# Altium schematic sheet Filter.SchDoc
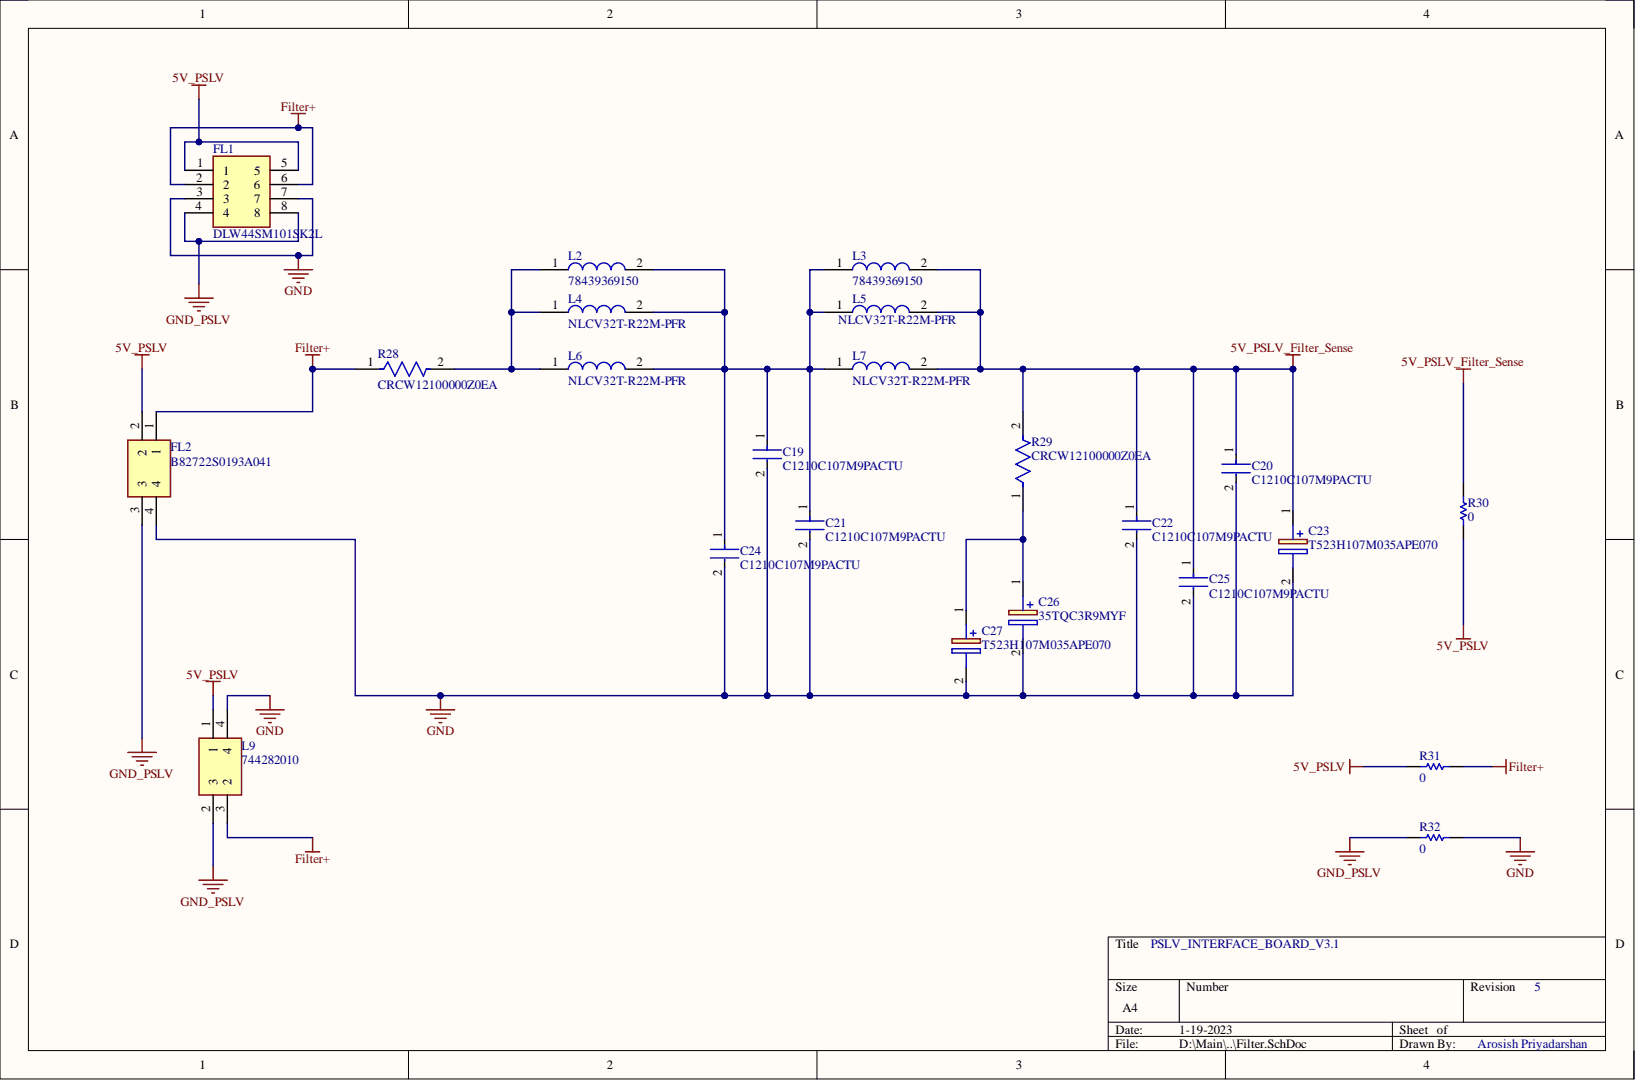
[diagram p0: Filter.SchDoc]

## Parts
C19  C1210C107M9PACTU  [FP-C1210C107M9PACTU-MFG]
C20  C1210C107M9PACTU  [FP-C1210C107M9PACTU-MFG]
C21  C1210C107M9PACTU  [FP-C1210C107M9PACTU-MFG]
C22  C1210C107M9PACTU  [FP-C1210C107M9PACTU-MFG]
C23  T523H107M035APE070  [CAPPM7360X200N]
C24  C1210C107M9PACTU  [FP-C1210C107M9PACTU-MFG]
C25  C1210C107M9PACTU  [FP-C1210C107M9PACTU-MFG]
C26  35TQC3R9MYF  [POSCAP_B1_B1S_B1G_B15G_B2_B2S]
C27  T523H107M035APE070  [CAPPM7360X200N]
FL1  DLW44SM101SK2L  [DLW44SM101SK2B]
FL2  B82722S0193A041  [B82722S0103A020]
L2  78439369150  [78439369150]
L3  78439369150  [78439369150]
L4  NLCV32T-R22M-PFR  [INDM3225X240N]
L5  NLCV32T-R22M-PFR  [INDM3225X240N]
L6  NLCV32T-R22M-PFR  [INDM3225X240N]
L7  NLCV32T-R22M-PFR  [INDM3225X240N]
L9  744282010  [WE-SCC_1260/121070]
R28  CRCW12100000Z0EA  [FP-CRCW1210-e3-IPC_A]
R29  CRCW12100000Z0EA  [FP-CRCW1210-e3-IPC_A]
R30  0  [RESC3216X70X50LL05T20]
R31  0  [RESC3216X70X50LL05T20]
R32  0  [RESC3216X70X50LL05T20]

## Nets
5V_PSLV: FL1.1 FL1.5 FL2.2 L9.1 R30.2 R31.1
5V_PSLV_Filter_Sense: C20.1 C22.1 C23.1 C25.1 L3.2 L5.2 L7.2 R29.2 R30.1
Filter+: FL1.2 FL1.6 FL2.1 L9.3 R28.1 R31.2
GND: C19.2 C20.2 C21.2 C22.2 C23.2 C24.2 C25.2 C26.2 C27.2 FL1.3 FL1.7 FL2.4 L9.4 R32.2
GND_PSLV: FL1.4 FL1.8 FL2.3 L9.2 R32.1
NetC19_1: C19.1 C21.1 C24.1 L2.2 L3.1 L4.2 L5.1 L6.2 L7.1
NetC26_1: C26.1 C27.1 R29.1
NetL2_1: L2.1 L4.1 L6.1 R28.2

## Library data of the parts
C19-C22 C24 C25 = CMP-2000-06018-2: CAP CER 100UF 6.3V X5R 1210; Dielectric Material: Ceramic; Dissipation Factor: 5%; Tolerance: 20%; Capacitance: 100uF; Case Code (Imperial): 1210; Case Code (Metric): 3225; Case/Package: 1210; Component Kind: Standard; Construction: Flat; Contact Plating: Tin; Depth: 2.5mm; Dielectric: X5R; Height: 2.5mm; Lead Free: Lead Free; Length: 3.2mm; Library Name: Altium Content Vault; Max Operating Temperature: 85°C; Min Operating Temperature: -55°C; Mount: Surface Mount; Number of Pins: 2; Package Quantity: 1; Packaging: Tape and Reel; Radiation Hardening: No; REACH SVHC: No SVHC; RoHS Compliant: Yes; Series: C; Termination: SMD/SMT; Thickness: 0.11inch; Voltage: 6.3V; Voltage Rating: 6.3V; Voltage Rating (DC): 6.3V; Width: 2.5mm
C23 C27 = T523H107M035APE070: Capacitor Polarised; Manufacturer_Name: KEMET; Arrow Part Number: T523H107M035APE070; Arrow Price/Stock: https://www.arrow.com/en/products/t523h107m035ape070/kemet-corporation; Component Kind: Standard; Datasheet Link: https://content.kemet.com/datasheets/KEM_T2079_SSD.pdf; Height: 2mm; Manufacturer_Part_Number: T523H107M035APE070; Mouser Part Number: 80-T523H107M35APE070; Mouser Price/Stock: https://www.mouser.co.uk/ProductDetail/KEMET/T523H107M035APE070?qs=unwgFEO1A6s1KPLWumNkZA%3D%3D
C26 = 35TQC3R9MYF: Capacitor Polarised; Manufacturer_Name: Panasonic; Arrow Part Number: 35TQC3R9MYF; Arrow Price/Stock: https://www.arrow.com/en/products/35tqc3r9myf/panasonic; Component Kind: Standard; Datasheet Link: http://industrial.panasonic.com/cdbs/www-data/pdf/AAA8000/AAA8000C62.pdf; Manufacturer_Part_Number: 35TQC3R9MYF; Mouser Part Number: 667-35TQC3R9MYF; Mouser Price/Stock: https://www.mouser.co.uk/ProductDetail/Panasonic/35TQC3R9MYF?qs=OE1iw1LrrPH4tD8vcXvqxw%3D%3D
FL1 = DLW44SM101SK2L: Filter; Manufacturer_Name: Murata Electronics; Component Kind: Standard; Datasheet Link: https://www.murata.com/en-us/products/productdetail?partno=DLW44SM101SK2%23; Height: 1.5mm; Manufacturer_Part_Number: DLW44SM101SK2L; Mouser Part Number: 81-DLW44SM101SK2L; Mouser Price/Stock: https://www.mouser.co.uk/ProductDetail/Murata-Electronics/DLW44SM101SK2L?qs=7EBvPakHacXuNrKukXyu4w%3D%3D
FL2 = B82722S0193A041: Filter; Manufacturer_Name: TDK; Component Kind: Standard; Datasheet Link: https://product.tdk.com/system/files/dam/doc/product/emc/emc/cmf_cmc/data_sheet/30/db/ind_2008/b82722s0.pdf; Height: 12.7mm; Manufacturer_Part_Number: B82722S0193A041; Mouser Part Number: 871-B82722S0193A041; Mouser Price/Stock: https://www.mouser.co.uk/ProductDetail/EPCOS-TDK/B82722S0193A041?qs=Li%252BoUPsLEnuKP3edbmg7Qw%3D%3D
L2 L3 = 78439369150: Inductor; Manufacturer_Name: Wurth Elektronik; Component Kind: Standard; Datasheet Link: https://www.mouser.in/datasheet/2/445/78439369150-2936612.pdf; Height: 9.1mm; Manufacturer_Part_Number: 78439369150; Mouser Part Number: 710-78439369150; Mouser Price/Stock: https://www.mouser.co.uk/ProductDetail/Wurth-Elektronik/78439369150?qs=Znm5pLBrcAIcI33uuX1Vag%3D%3D
L4-L7 = NLCV32T-R22M-PFR: Inductor; Manufacturer_Name: TDK; Arrow Part Number: NLCV32T-R22M-PFR; Arrow Price/Stock: https://www.arrow.com/en/products/nlcv32t-r22m-pfr/tdk; Component Kind: Standard; Datasheet Link: https://product.tdk.com/system/files/dam/doc/product/inductor/inductor/smd/catalog/inductor_commercial_decoupling_nlcv32-pfr_en.pdf; Height: 2.4mm; Manufacturer_Part_Number: NLCV32T-R22M-PFR; Mouser Part Number: 810-NLCV32T-R22M-PFR; Mouser Price/Stock: https://www.mouser.com/Search/Refine.aspx?Keyword=810-NLCV32T-R22M-PFR
L9 = 744282010: Inductor; Manufacturer_Name: Wurth Elektronik; Component Kind: Standard; Manufacturer_Part_Number: 744282010; Mouser Part Number: 710-744282010; Mouser Price/Stock: https://www.mouser.co.uk/ProductDetail/Wurth-Elektronik/744282010?qs=c50eh9DPO4PZUn%2FpIKDQTg%3D%3D
R28 R29 = CMP-2005-01987-2: RES SMD 205K OHM 1% 1/2W 1992; Max Power Dissipation: 500mW; Resistance: 0mR; Temperature Coefficient: 0ppm/°C; Voltage Rating: 200V; Case Code (Imperial): 1210; Case Code (Metric): 3225; Case/Package: 1210; Component Kind: Standard; Composition: Thick Film; Contact Plating: Tin; Depth: 2.5mm; Features: Automotive AEC-Q200; Height: 0.55mm; Length: 3.2mm; Library Name: Altium Content Vault; Max Operating Temperature: 155°C; Military Standard: Not; Min Operating Temperature: -55°C; Mount: Surface Mount; Number of Terminations: 2; Package Quantity: 1; Packaging: Tape and Reel; Power Rating: 500mW; Radiation Hardening: No; REACH SVHC: No SVHC; Termination: SMD/SMT; Weight: 0.000564oz; Width: 0.098inch
R30-R32 = CMP-2003-00541-1: Manufacturer: Panasonic; Part Number: ERJ-8GEY0R00V; Component Kind: Standard; Library Name: Altium Content Vault
